# Altium schematic sheet Sheet2.SchDoc(Sheet2)
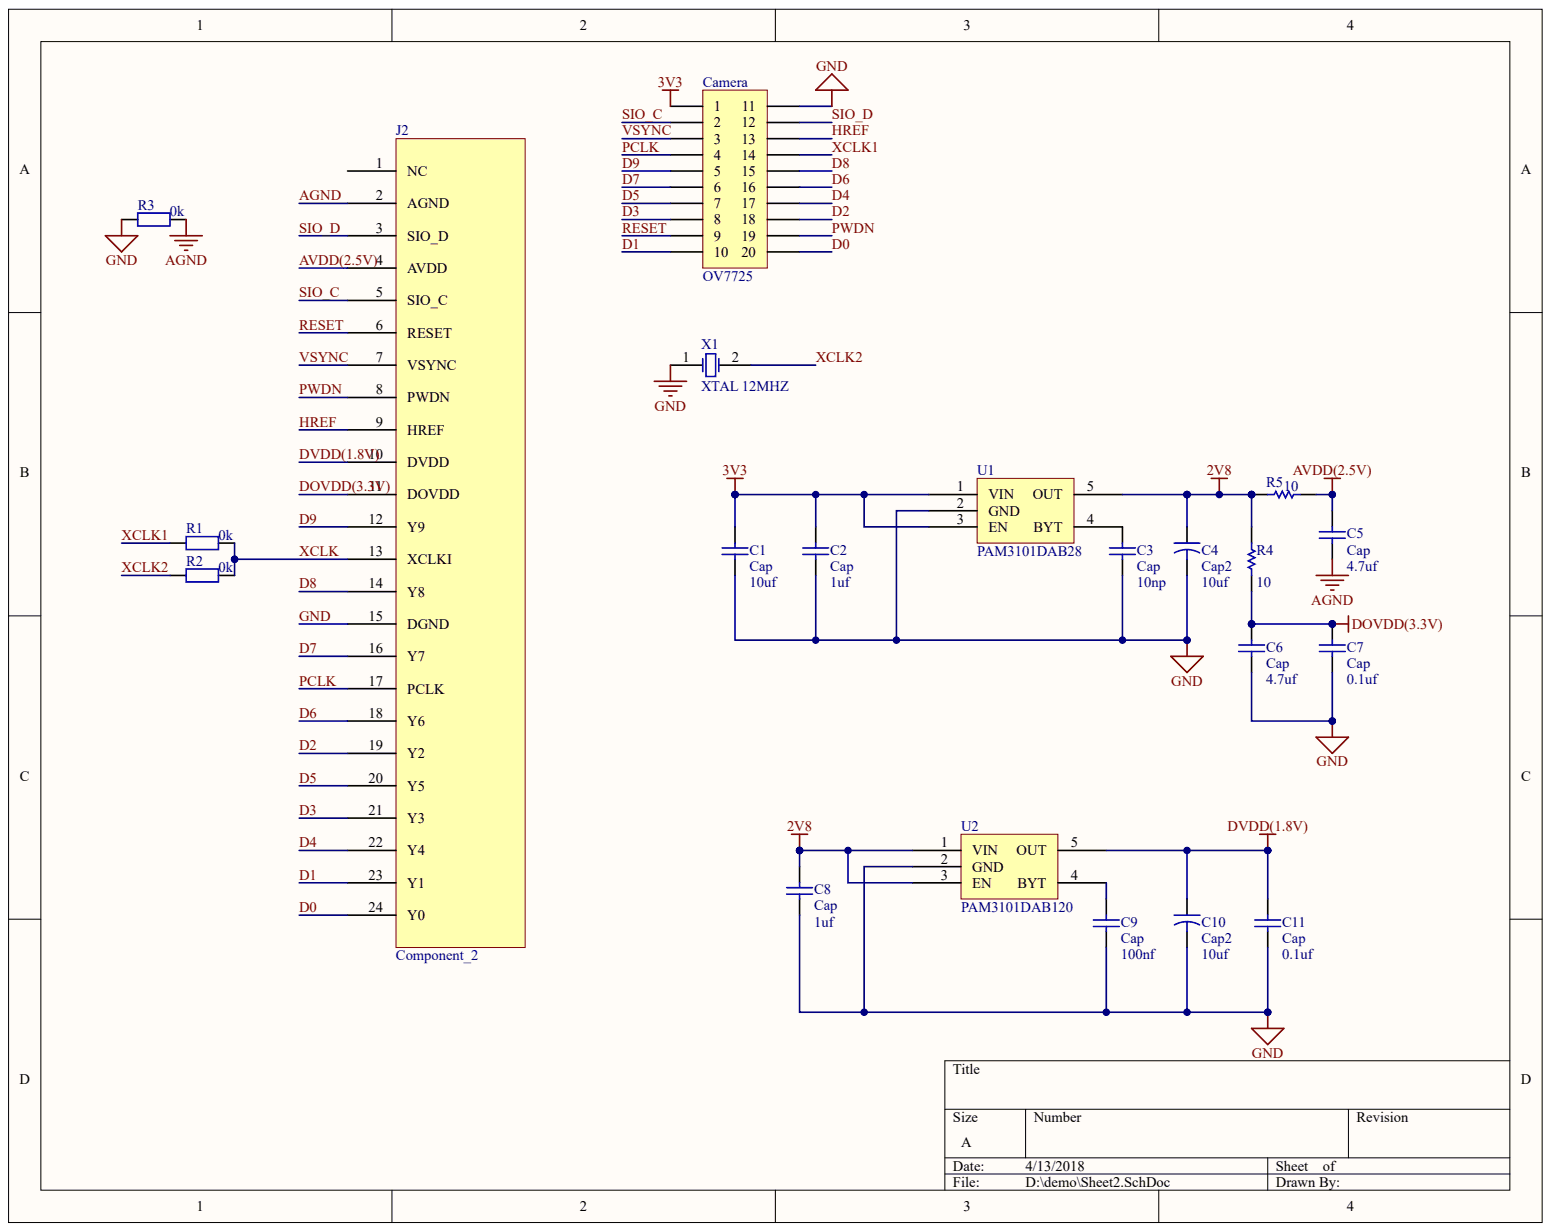
[diagram p0: Sheet2.SchDoc]

## Parts
C1  Cap  value 10uf
C2  Cap  value 1uf
C3  Cap  value 10np
C4  Cap2  value 10uf
C5  Cap  value 4.7uf
C6  Cap  value 4.7uf
C7  Cap  value 0.1uf
C8  Cap  value 1uf
C9  Cap  value 100nf
C10  Cap2  value 10uf
C11  Cap  value 0.1uf
Camera  OV7725
J2  Component_2
R1  value 0k  (hidden comment: Res2)
R2  value 0k  (hidden comment: Res2)
R3  value 0k  (hidden comment: Res2)
R4  value 10  (hidden comment: Res1)
R5  value 10  (hidden comment: Res1)
U1  PAM3101DAB28
U2  PAM3101DAB120
X1  XTAL 12MHZ

## Nets
2V8: C4.1 C8.2 R4.2 R5.1 U1.5(OUT) U2.1(VIN) U2.3(EN)
3V3: C1.1 C2.2 Camera.1 U1.1(VIN) U1.3(EN)
AGND: C5.1 J2.2(AGND) R3.2
AVDD(2.5V): C5.2 J2.4(AVDD) R5.2
D0: Camera.20 J2.24(Y0)
D1: Camera.10 J2.23(Y1)
D2: Camera.18 J2.19(Y2)
D3: Camera.8 J2.21(Y3)
D4: Camera.17 J2.22(Y4)
D5: Camera.7 J2.20(Y5)
D6: Camera.16 J2.18(Y6)
D7: Camera.6 J2.16(Y7)
D8: Camera.15 J2.14(Y8)
D9: Camera.5 J2.12(Y9)
DOVDD(3.3V): C6.2 C7.2 J2.11(DOVDD) R4.1
DVDD(1.8V): C10.1 C11.2 J2.10(DVDD) U2.5(OUT)
GND: C1.2 C2.1 C3.1 C4.2 C6.1 C7.1 C8.1 C9.1 C10.2 C11.1 Camera.11 J2.15(DGND) R3.1 U1.2(GND) U2.2(GND) X1.1
HREF: Camera.13 J2.9(HREF)
PCLK: Camera.4 J2.17(PCLK)
PWDN: Camera.19 J2.8(PWDN)
RESET: Camera.9 J2.6(RESET)
SIO_C: Camera.2 J2.5(SIO_C)
SIO_D: Camera.12 J2.3(SIO_D)
VSYNC: Camera.3 J2.7(VSYNC)
XCLK: J2.13(XCLKI) R1.2 R2.2
XCLK1: Camera.14 R1.1
XCLK2: R2.1 X1.2
NetC3_2: C3.2 U1.4(BYT)
NetC9_2: C9.2 U2.4(BYT)

Not connected: J2 1(NC)

## Library data of the parts
C1-C11 Camera J2 R1-R5 U1 U2 X1 = (no symbol name)
  C1-C3 C5-C9 C11: Published: 8-Jun-2000; LatestRevisionDate: 17-Jul-2002; LatestRevisionNote: Re-released for DXP Platform.; PackageReference: RAD-0.3; PackageDescription: Radial Cap, Thru-Hole; 2 Leads; 0.3 in Pin Spacing
  C4 C10: Published: 23-Sep-2002; PackageReference: CAPR5-4X5; PackageDescription: Capacitor; 2 Leads
  Camera: LatestRevisionDate: 17-Jul-2002; LatestRevisionNote: Re-released for DXP Platform.
  R1-R3: Published: 8-Jun-2000; LatestRevisionDate: 17-Jul-2002; LatestRevisionNote: Re-released for DXP Platform.; PackageReference: AXIAL-0.4; PackageDescription: Axial Device, Thru-Hole; 2 Leads; 0.4 in Pin Spacing
  R4 R5: Published: 8-Jun-2000; LatestRevisionDate: 17-Jul-2002; LatestRevisionNote: Re-released for DXP Platform.; PackageReference: AXIAL-0.3; PackageDescription: Axial Device, Thru-Hole; 2 Leads; 0.3 in Pin Spacing
  X1: Published: 8-Jun-2000; LatestRevisionDate: 17-Jul-2002; LatestRevisionNote: Re-released for DXP Platform.; PackageReference: R38; PackageDescription: Crystal, Thru-Hole; 2 Leads; Body 3.1 x 8.2 mm (Dia.xH)
  C1-C11 Camera R1-R5 X1: Publisher: Altium Limited
